# Altium schematic sheet SNES TST Sheet3.SchDoc
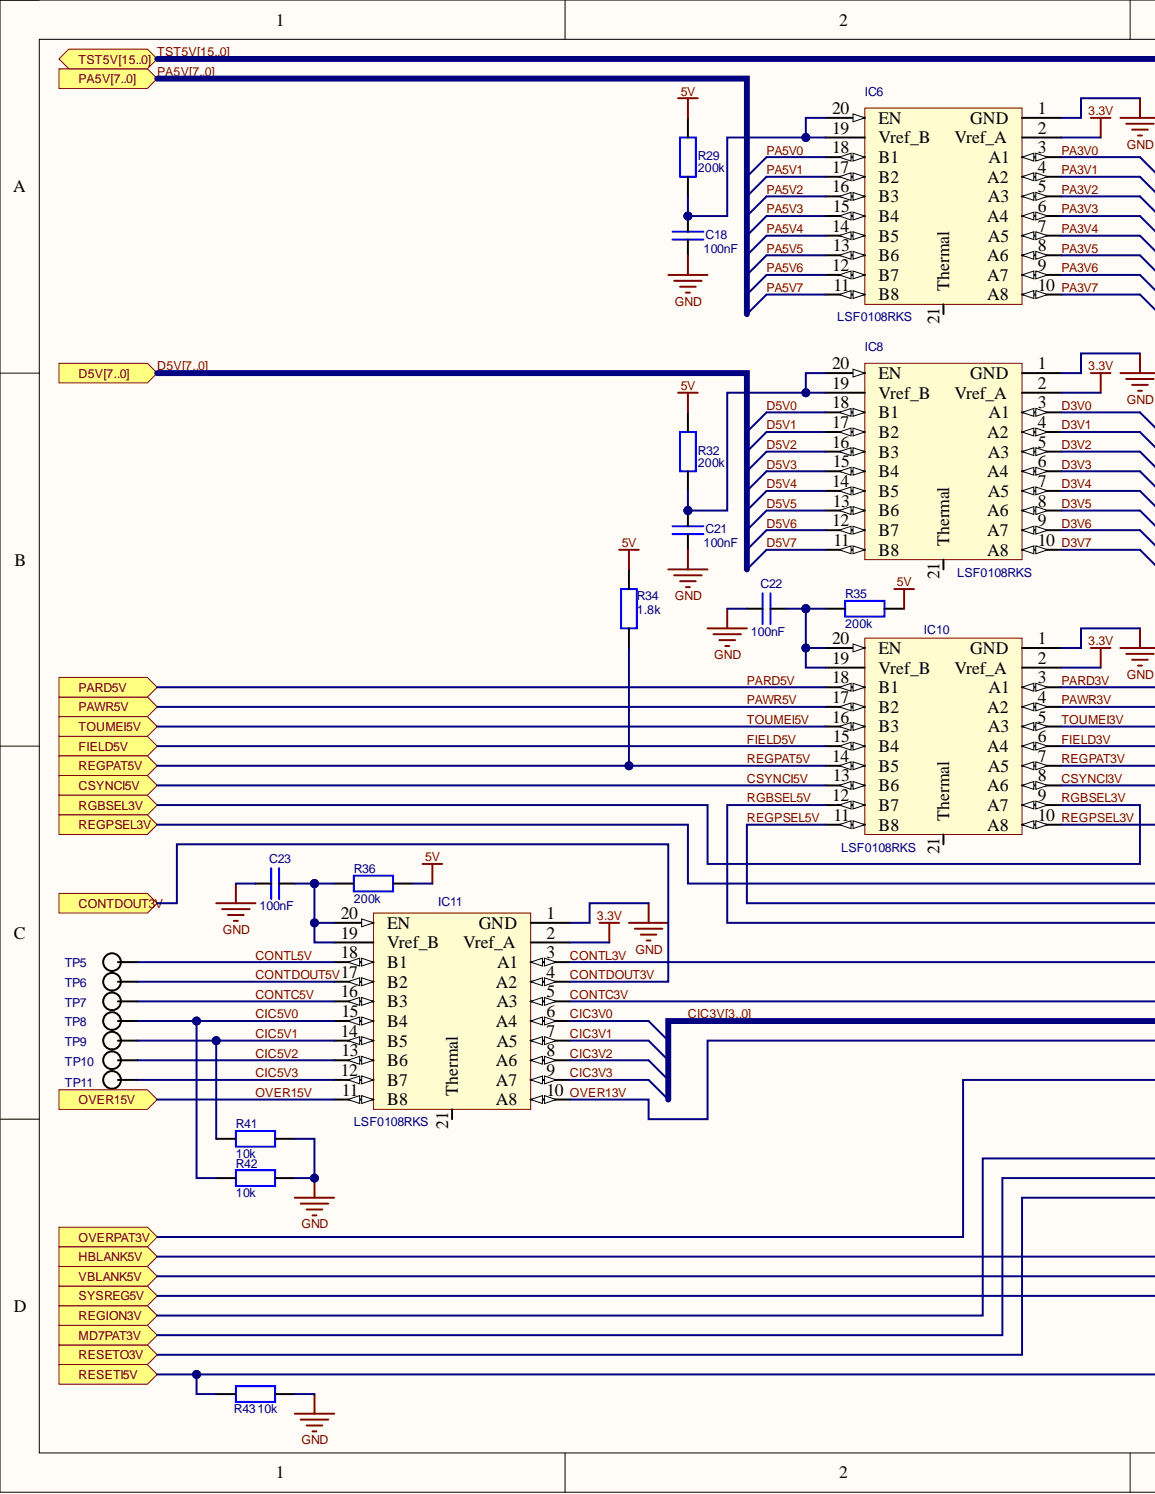
[diagram p0: SNES TST Sheet3.SchDoc, left]
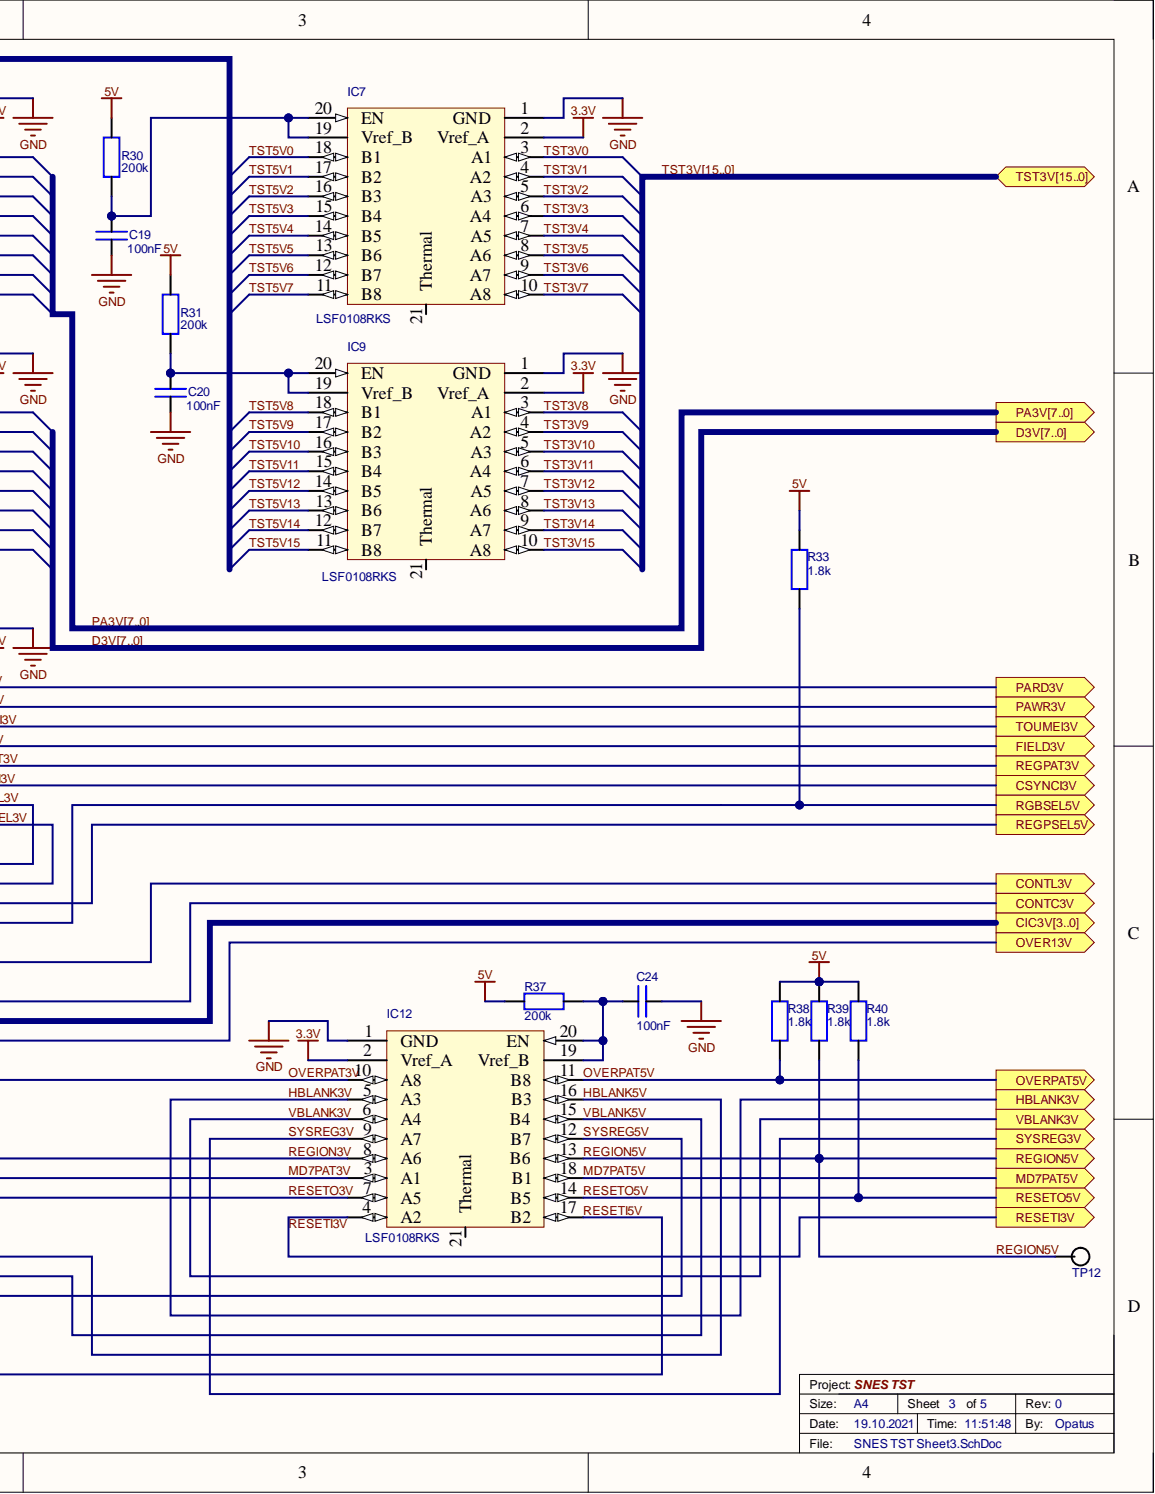
[diagram p1: SNES TST Sheet3.SchDoc, right]

## Parts
C18  100nF  [Cap_0402_Chip]
C19  100nF  [Cap_0402_Chip]
C20  100nF  [Cap_0402_Chip]
C21  100nF  [Cap_0402_Chip]
C22  100nF  [Cap_0402_Chip]
C23  100nF  [Cap_0402_Chip]
C24  100nF  [Cap_0402_Chip]
IC6  LSF0108  Manufacturer_Part_Number: LSF0108RKS  [VQFN20]
IC7  LSF0108  Manufacturer_Part_Number: LSF0108RKS  [VQFN20]
IC8  LSF0108  Manufacturer_Part_Number: LSF0108RKS  [VQFN20]
IC9  LSF0108  Manufacturer_Part_Number: LSF0108RKS  [VQFN20]
IC10  LSF0108  Manufacturer_Part_Number: LSF0108RKS  [VQFN20]
IC11  LSF0108  Manufacturer_Part_Number: LSF0108RKS  [VQFN20]
IC12  LSF0108  Manufacturer_Part_Number: LSF0108RKS  [VQFN20]
R29  200k  [Res_0402_Chip]
R30  200k  [Res_0402_Chip]
R31  200k  [Res_0402_Chip]
R32  200k  [Res_0402_Chip]
R33  1.8k  [Res_0402_Chip]
R34  1.8k  [Res_0402_Chip]
R35  200k  [Res_0402_Chip]
R36  200k  [Res_0402_Chip]
R37  200k  [Res_0402_Chip]
R38  1.8k  [Res_0402_Chip]
R39  1.8k  [Res_0402_Chip]
R40  1.8k  [Res_0402_Chip]
R41  10k  [Res_0402_Chip]
R42  10k  [Res_0402_Chip]
R43  10k  [Res_0402_Chip]
TP5  Pin Connector  [Pin Connector Small]  (value not drawn)  (no BOM)
TP6  Pin Connector  [Pin Connector Small]  (value not drawn)  (no BOM)
TP7  Pin Connector  [Pin Connector Small]  (value not drawn)  (no BOM)
TP8  Pin Connector  [Pin Connector Small]  (value not drawn)  (no BOM)
TP9  Pin Connector  [Pin Connector Small]  (value not drawn)  (no BOM)
TP10  Pin Connector  [Pin Connector Small]  (value not drawn)  (no BOM)
TP11  Pin Connector  [Pin Connector Small]  (value not drawn)  (no BOM)
TP12  Pin Connector  [Pin Connector Small]  (value not drawn)  (no BOM)

## Ports
CIC3V0, CIC3V1, CIC3V2, CIC3V3, CIC3V[3..0], CONTC3V, CONTDOUT3V, CONTL3V, CSYNCI3V, CSYNCI5V, D3V0, D3V1, D3V2, D3V3, D3V4, D3V5, D3V6, D3V7, D3V[7..0], D5V0, D5V1, D5V2, D5V3, D5V4, D5V5, D5V6, D5V7, D5V[7..0], FIELD3V, FIELD5V, HBLANK3V, HBLANK5V, MD7PAT3V, MD7PAT5V, OVER13V, OVER15V, OVERPAT3V, OVERPAT5V, PA3V0, PA3V1, PA3V2, PA3V3, PA3V4, PA3V5, PA3V6, PA3V7, PA3V[7..0], PA5V0, PA5V1, PA5V2, PA5V3, PA5V4, PA5V5, PA5V6, PA5V7, PA5V[7..0], PARD3V, PARD5V, PAWR3V, PAWR5V, REGION3V, REGION5V, REGPAT3V, REGPAT5V, REGPSEL3V, REGPSEL5V, RESETI3V, RESETI5V, RESETO3V, RESETO5V, RGBSEL3V, RGBSEL5V, SYSREG3V, SYSREG5V, TOUMEI3V, TOUMEI5V, TST3V0, TST3V1, TST3V2, TST3V3, TST3V4, TST3V5, TST3V6, TST3V7, TST3V8, TST3V9, TST3V10, TST3V11, TST3V12, TST3V13, TST3V14, TST3V15, TST3V[15..0], TST5V0, TST5V1, TST5V2, TST5V3, TST5V4, TST5V5, TST5V6, TST5V7, TST5V8, TST5V9, TST5V10, TST5V11, TST5V12, TST5V13, TST5V14, TST5V15, TST5V[15..0], VBLANK3V, VBLANK5V

## Nets
3.3V: IC6.2(Vref_A) IC7.2(Vref_A) IC8.2(Vref_A) IC9.2(Vref_A) IC10.2(Vref_A) IC11.2(Vref_A) IC12.2(Vref_A)
5V: R29.2 R30.2 R31.2 R32.2 R33.1 R34.1 R35.1 R36.1 R37.2 R38.1 R39.1 R40.1
GND: C18.2 C19.2 C20.2 C21.2 C22.1 C23.1 C24.2 IC6.1(GND) IC7.1(GND) IC8.1(GND) IC9.1(GND) IC10.1(GND) IC11.1(GND) IC12.1(GND) R41.1 R42.1 R43.2
CIC3V0: IC11.6(A4) ; port CIC3V[3..0]  [also labelled CIC3V]
CIC3V1: IC11.7(A5) ; port CIC3V[3..0]  [also labelled CIC3V]
CIC3V2: IC11.8(A6) ; port CIC3V[3..0]  [also labelled CIC3V]
CIC3V3: IC11.9(A7) ; port CIC3V[3..0]  [also labelled CIC3V]
CIC5V0: IC11.15(B4) R42.2 TP8.1
CIC5V1: IC11.14(B5) R41.2 TP9.1
CIC5V2: IC11.13(B6) TP10.1
CIC5V3: IC11.12(B7) TP11.1
CONTC3V: IC11.5(A3) ; port CONTC3V
CONTC5V: IC11.16(B3) TP7.1
CONTDOUT3V: IC11.4(A2) ; port CONTDOUT3V
CONTDOUT5V: IC11.17(B2) TP6.1
CONTL3V: IC11.3(A1) ; port CONTL3V
CONTL5V: IC11.18(B1) TP5.1
CSYNCI3V: IC10.8(A6) ; port CSYNCI3V
CSYNCI5V: IC10.13(B6) ; port CSYNCI5V
D3V0: IC8.3(A1) ; port D3V[7..0]  [also labelled D3V]
D3V1: IC8.4(A2) ; port D3V[7..0]  [also labelled D3V]
D3V2: IC8.5(A3) ; port D3V[7..0]  [also labelled D3V]
D3V3: IC8.6(A4) ; port D3V[7..0]  [also labelled D3V]
D3V4: IC8.7(A5) ; port D3V[7..0]  [also labelled D3V]
D3V5: IC8.8(A6) ; port D3V[7..0]  [also labelled D3V]
D3V6: IC8.9(A7) ; port D3V[7..0]  [also labelled D3V]
D3V7: IC8.10(A8) ; port D3V[7..0]  [also labelled D3V]
D5V0: IC8.18(B1) ; port D5V[7..0]  [also labelled D5V]
D5V1: IC8.17(B2) ; port D5V[7..0]  [also labelled D5V]
D5V2: IC8.16(B3) ; port D5V[7..0]  [also labelled D5V]
D5V3: IC8.15(B4) ; port D5V[7..0]  [also labelled D5V]
D5V4: IC8.14(B5) ; port D5V[7..0]  [also labelled D5V]
D5V5: IC8.13(B6) ; port D5V[7..0]  [also labelled D5V]
D5V6: IC8.12(B7) ; port D5V[7..0]  [also labelled D5V]
D5V7: IC8.11(B8) ; port D5V[7..0]  [also labelled D5V]
FIELD3V: IC10.6(A4) ; port FIELD3V
FIELD5V: IC10.15(B4) ; port FIELD5V
HBLANK3V: IC12.5(A3) ; port HBLANK3V
HBLANK5V: IC12.16(B3) ; port HBLANK5V
MD7PAT3V: IC12.3(A1) ; port MD7PAT3V
MD7PAT5V: IC12.18(B1) ; port MD7PAT5V
OVER13V: IC11.10(A8) ; port OVER13V
OVER15V: IC11.11(B8) ; port OVER15V
OVERPAT3V: IC12.10(A8) ; port OVERPAT3V
OVERPAT5V: IC12.11(B8) R38.2 ; port OVERPAT5V
PA3V0: IC6.3(A1) ; port PA3V[7..0]  [also labelled PA3V]
PA3V1: IC6.4(A2) ; port PA3V[7..0]  [also labelled PA3V]
PA3V2: IC6.5(A3) ; port PA3V[7..0]  [also labelled PA3V]
PA3V3: IC6.6(A4) ; port PA3V[7..0]  [also labelled PA3V]
PA3V4: IC6.7(A5) ; port PA3V[7..0]  [also labelled PA3V]
PA3V5: IC6.8(A6) ; port PA3V[7..0]  [also labelled PA3V]
PA3V6: IC6.9(A7) ; port PA3V[7..0]  [also labelled PA3V]
PA3V7: IC6.10(A8) ; port PA3V[7..0]  [also labelled PA3V]
PA5V0: IC6.18(B1) ; port PA5V[7..0]  [also labelled PA5V]
PA5V1: IC6.17(B2) ; port PA5V[7..0]  [also labelled PA5V]
PA5V2: IC6.16(B3) ; port PA5V[7..0]  [also labelled PA5V]
PA5V3: IC6.15(B4) ; port PA5V[7..0]  [also labelled PA5V]
PA5V4: IC6.14(B5) ; port PA5V[7..0]  [also labelled PA5V]
PA5V5: IC6.13(B6) ; port PA5V[7..0]  [also labelled PA5V]
PA5V6: IC6.12(B7) ; port PA5V[7..0]  [also labelled PA5V]
PA5V7: IC6.11(B8) ; port PA5V[7..0]  [also labelled PA5V]
PARD3V: IC10.3(A1) ; port PARD3V
PARD5V: IC10.18(B1) ; port PARD5V
PAWR3V: IC10.4(A2) ; port PAWR3V
PAWR5V: IC10.17(B2) ; port PAWR5V
REGION3V: IC12.8(A6) ; port REGION3V
REGION5V: IC12.13(B6) R39.2 TP12.1 ; port REGION5V
REGPAT3V: IC10.7(A5) ; port REGPAT3V
REGPAT5V: IC10.14(B5) R34.2 ; port REGPAT5V
REGPSEL3V: IC10.10(A8) ; port REGPSEL3V
REGPSEL5V: IC10.11(B8) ; port REGPSEL5V
RESETI3V: IC12.4(A2) ; port RESETI3V
RESETI5V: IC12.17(B2) R43.1 ; port RESETI5V
RESETO3V: IC12.7(A5) ; port RESETO3V
RESETO5V: IC12.14(B5) R40.2 ; port RESETO5V
RGBSEL3V: IC10.9(A7) ; port RGBSEL3V
RGBSEL5V: IC10.12(B7) R33.2 ; port RGBSEL5V
SYSREG3V: IC12.9(A7) ; port SYSREG3V
SYSREG5V: IC12.12(B7) ; port SYSREG5V
TOUMEI3V: IC10.5(A3) ; port TOUMEI3V
TOUMEI5V: IC10.16(B3) ; port TOUMEI5V
TST3V0: IC7.3(A1) ; port TST3V[15..0]  [also labelled TST3V]
TST3V1: IC7.4(A2) ; port TST3V[15..0]  [also labelled TST3V]
TST3V2: IC7.5(A3) ; port TST3V[15..0]  [also labelled TST3V]
TST3V3: IC7.6(A4) ; port TST3V[15..0]  [also labelled TST3V]
TST3V4: IC7.7(A5) ; port TST3V[15..0]  [also labelled TST3V]
TST3V5: IC7.8(A6) ; port TST3V[15..0]  [also labelled TST3V]
TST3V6: IC7.9(A7) ; port TST3V[15..0]  [also labelled TST3V]
TST3V7: IC7.10(A8) ; port TST3V[15..0]  [also labelled TST3V]
TST3V8: IC9.3(A1) ; port TST3V[15..0]  [also labelled TST3V]
TST3V9: IC9.4(A2) ; port TST3V[15..0]  [also labelled TST3V]
TST3V10: IC9.5(A3) ; port TST3V[15..0]  [also labelled TST3V]
TST3V11: IC9.6(A4) ; port TST3V[15..0]  [also labelled TST3V]
TST3V12: IC9.7(A5) ; port TST3V[15..0]  [also labelled TST3V]
TST3V13: IC9.8(A6) ; port TST3V[15..0]  [also labelled TST3V]
TST3V14: IC9.9(A7) ; port TST3V[15..0]  [also labelled TST3V]
TST3V15: IC9.10(A8) ; port TST3V[15..0]  [also labelled TST3V]
TST5V0: IC7.18(B1) ; port TST5V[15..0]  [also labelled TST5V]
TST5V1: IC7.17(B2) ; port TST5V[15..0]  [also labelled TST5V]
TST5V2: IC7.16(B3) ; port TST5V[15..0]  [also labelled TST5V]
TST5V3: IC7.15(B4) ; port TST5V[15..0]  [also labelled TST5V]
TST5V4: IC7.14(B5) ; port TST5V[15..0]  [also labelled TST5V]
TST5V5: IC7.13(B6) ; port TST5V[15..0]  [also labelled TST5V]
TST5V6: IC7.12(B7) ; port TST5V[15..0]  [also labelled TST5V]
TST5V7: IC7.11(B8) ; port TST5V[15..0]  [also labelled TST5V]
TST5V8: IC9.18(B1) ; port TST5V[15..0]  [also labelled TST5V]
TST5V9: IC9.17(B2) ; port TST5V[15..0]  [also labelled TST5V]
TST5V10: IC9.16(B3) ; port TST5V[15..0]  [also labelled TST5V]
TST5V11: IC9.15(B4) ; port TST5V[15..0]  [also labelled TST5V]
TST5V12: IC9.14(B5) ; port TST5V[15..0]  [also labelled TST5V]
TST5V13: IC9.13(B6) ; port TST5V[15..0]  [also labelled TST5V]
TST5V14: IC9.12(B7) ; port TST5V[15..0]  [also labelled TST5V]
TST5V15: IC9.11(B8) ; port TST5V[15..0]  [also labelled TST5V]
VBLANK3V: IC12.6(A4) ; port VBLANK3V
VBLANK5V: IC12.15(B4) ; port VBLANK5V
NetC18_1: C18.1 IC6.19(Vref_B) IC6.20(EN) R29.1
NetC19_1: C19.1 IC7.19(Vref_B) IC7.20(EN) R30.1
NetC20_1: C20.1 IC9.19(Vref_B) IC9.20(EN) R31.1
NetC21_1: C21.1 IC8.19(Vref_B) IC8.20(EN) R32.1
NetC22_2: C22.2 IC10.19(Vref_B) IC10.20(EN) R35.2
NetC23_2: C23.2 IC11.19(Vref_B) IC11.20(EN) R36.2
NetC24_1: C24.1 IC12.19(Vref_B) IC12.20(EN) R37.1

Not connected: IC6 21(Thermal); IC7 21(Thermal); IC8 21(Thermal); IC9 21(Thermal); IC10 21(Thermal); IC11 21(Thermal); IC12 21(Thermal)

## Library data of the parts
C18-C24 = Capacitor: Dielectric: X7R; Nominal Voltage: 10V; Tolerance: +-20%; Component Kind: Standard; Library Name: PCB.SchLib; Value: 100nF
IC6-IC12 = LSF0108DCUR: 8-bit level shifter; Vendor: Texas Instruments; Component Kind: Standard; Library Name: PCB.SchLib
R29-R43 = R: Tolerance: +-1%; Component Kind: Standard; Library Name: PCB.SchLib
  R29-R32 R35-R37: Value: 200k
  R33 R34 R38-R40: Value: 1.8k
  R41-R43: Value: 10k
TP5-TP12 = Pin Connector: Library Name: PCB.SchLib
